# Altium schematic sheet Bop_it.SchDoc
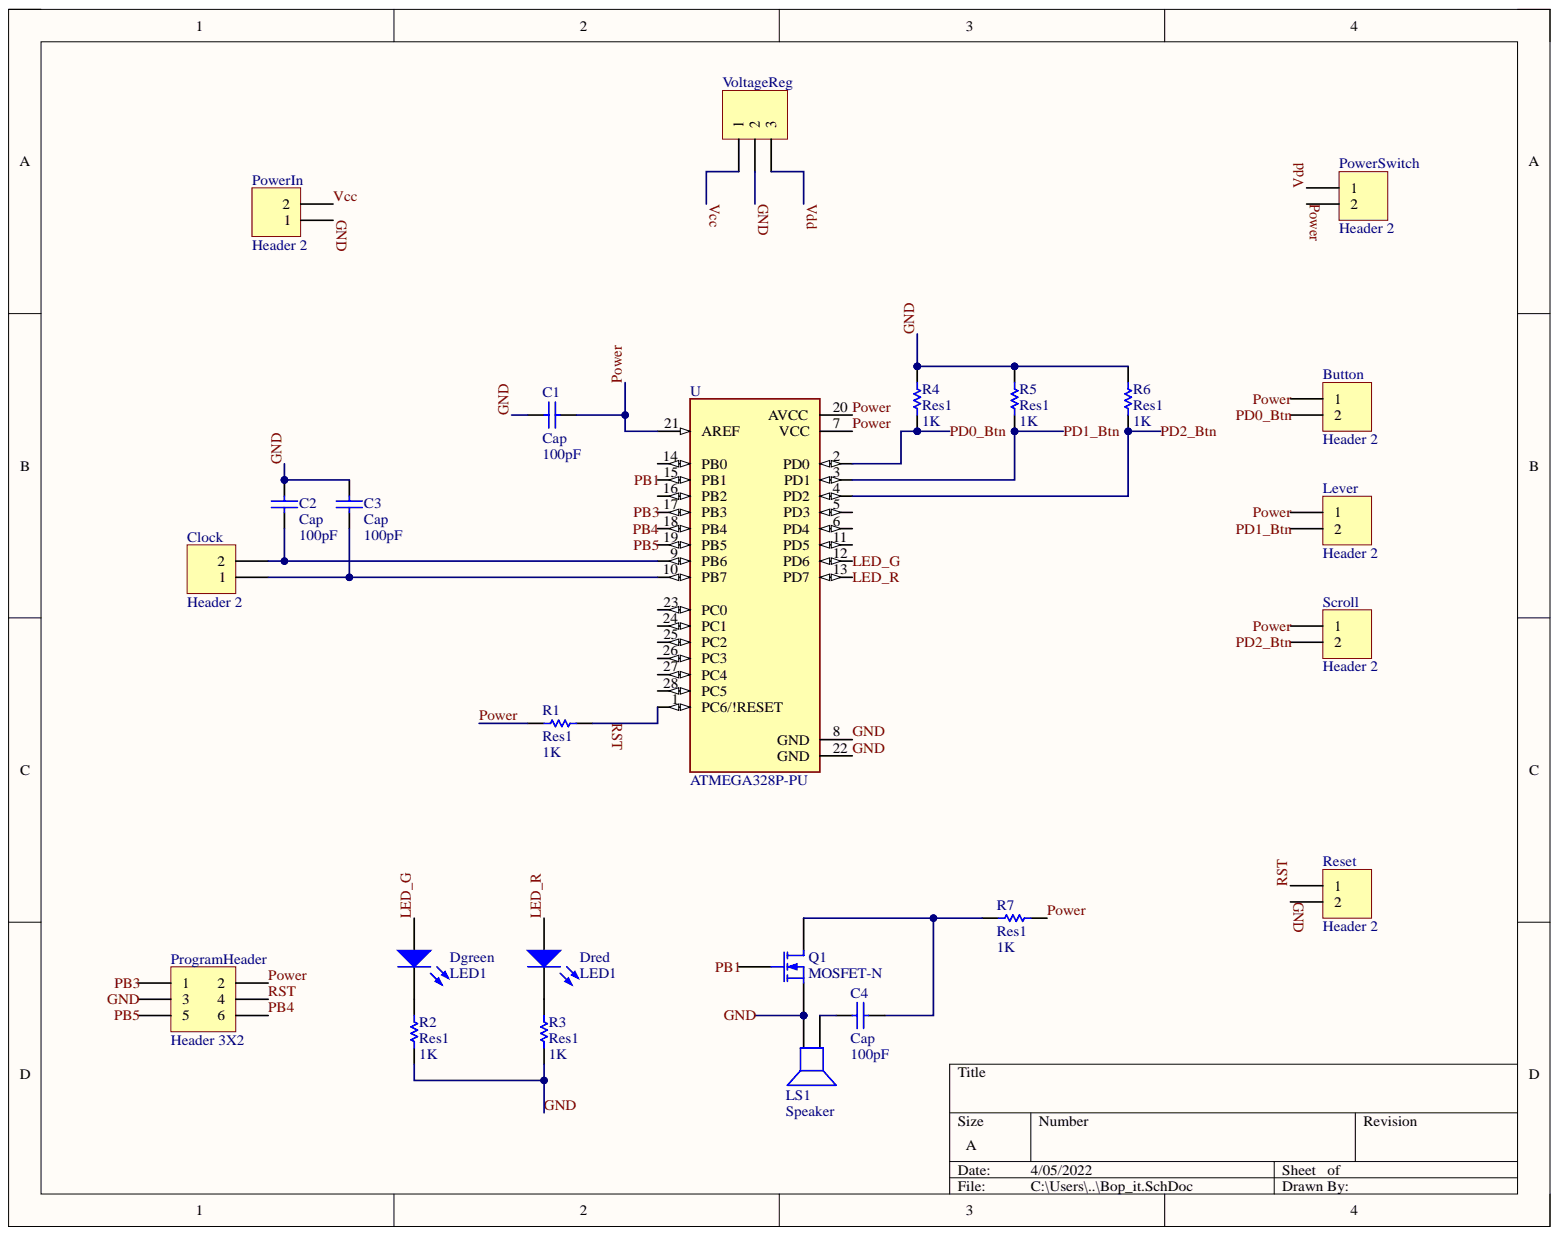
[diagram p0: Bop_it.SchDoc]

## Parts
Button  Header 2  [HDR1X2]
C1  Cap  value 100pF  [RAD-0.3]
C2  Cap  value 100pF  [RAD-0.3]
C3  Cap  value 100pF  [RAD-0.3]
C4  Cap  value 100pF  [RAD-0.3]
Clock  Header 2  [HDR1X2]
Dgreen  LED1  [LED-1]
Dred  LED1  [LED-1]
Lever  Header 2  [HDR1X2]
LS1  Speaker  [PIN2]
PowerIn  Header 2  [HDR1X2]
PowerSwitch  Header 2  [HDR1X2]
ProgramHeader  Header 3X2  [HDR2X3]
Q1  MOSFET-N  [E3]
R1  Res1  value 1K  [AXIAL-0.3]
R2  Res1  value 1K  [AXIAL-0.3]
R3  Res1  value 1K  [AXIAL-0.3]
R4  Res1  value 1K  [AXIAL-0.3]
R5  Res1  value 1K  [AXIAL-0.3]
R6  Res1  value 1K  [AXIAL-0.3]
R7  Res1  value 1K  [AXIAL-0.3]
Reset  Header 2  [HDR1X2]
Scroll  Header 2  [HDR1X2]
U  ATMEGA328P-PU  [DIP794W46P254L2967H457Q28B]
VoltageReg  (no value)  [HDR1X3]

## Nets
GND: C1.1 C2.2 C3.1 LS1.2 PowerIn.1 ProgramHeader.3 Q1.3 R2.2 R3.2 R4.1 R5.1 R6.1 Reset.2 U.8(GND) U.22(GND) VoltageReg.2
LED_G: Dgreen.1 U.12(PD6)
LED_R: Dred.1 U.13(PD7)
PB1: Q1.2 U.15(PB1)
PB3: ProgramHeader.1 U.17(PB3)
PB4: ProgramHeader.6 U.18(PB4)
PB5: ProgramHeader.5 U.19(PB5)
PD0_Btn: Button.2 R4.2 U.2(PD0)
PD1_Btn: Lever.2 R5.2 U.3(PD1)
PD2_Btn: R6.2 Scroll.2 U.4(PD2)
Power: Button.1 C1.2 Lever.1 PowerSwitch.2 ProgramHeader.2 R1.1 R7.1 Scroll.1 U.7(VCC) U.20(AVCC) U.21(AREF)
RST: ProgramHeader.4 R1.2 Reset.1 U.1(PC6/!RESET)
Vcc: PowerIn.2 VoltageReg.1
Vdd: PowerSwitch.1 VoltageReg.3
NetC2_1: C2.1 Clock.2 U.9(PB6)
NetC3_2: C3.2 Clock.1 U.10(PB7)
NetC4_1: C4.1 LS1.1
NetC4_2: C4.2 Q1.1 R7.2
NetDgreen_2: Dgreen.2 R2.1
NetDred_2: Dred.2 R3.1

Not connected: U 5(PD3) 6(PD4) 11(PD5) 14(PB0) 16(PB2) 23(PC0) 24(PC1) 25(PC2) 26(PC3) 27(PC4) 28(PC5)

## Library data of the parts
Button Clock Lever PowerIn PowerSwitch Reset Scroll = Header 2: Header, 2-Pin; Component Kind: Standard; LatestRevisionDate: 17-Jul-2002; LatestRevisionNote: Re-released for DXP Platform.; Library Name: Miscellaneous Connectors.IntLib; Signal Integrity: Connector; Publisher: Altium Limited
C1-C3 = Cap: Capacitor; Component Kind: Standard; LatestRevisionDate: 17-Jul-2002; LatestRevisionNote: Re-released for DXP Platform.; Library Name: Miscellaneous Devices.IntLib; PackageDescription: Radial Cap, Thru-Hole; 2 Leads; 0.3 in Pin Spacing; PackageReference: RAD-0.3; Published: 8-Jun-2000; Signal Integrity: Cap; Simulation: CAP; Publisher: Altium Limited
C4 = Cap: Capacitor; Value: 100pF; Component Kind: Standard; LatestRevisionDate: 17-Jul-2002; LatestRevisionNote: Re-released for DXP Platform.; Library Name: Miscellaneous Devices.IntLib; PackageDescription: Radial Cap, Thru-Hole; 2 Leads; 0.3 in Pin Spacing; PackageReference: RAD-0.3; Published: 8-Jun-2000; Signal Integrity: Cap; Simulation: CAP; Publisher: Altium Limited
Dgreen Dred = LED1: Typical RED GaAs LED; Component Kind: Standard; LatestRevisionDate: 08-Jul-2005; LatestRevisionNote: Mech Layer 1 removed.; Library Name: Miscellaneous Devices.IntLib; PackageDescription: LED; 2 Leads; PackageReference: LED-1; Published: 8-Jun-2000; Simulation: LED1; Publisher: Altium Limited
LS1 = Speaker: Loudspeaker; Component Kind: Standard; LatestRevisionDate: 17-Jul-2002; LatestRevisionNote: Re-released for DXP Platform.; Library Name: Miscellaneous Devices.IntLib; PackageReference: PIN2; Published: 24-Mar-1999; Publisher: Altium Limited
ProgramHeader = Header 3X2: Header, 3-Pin, Dual row; Component Kind: Standard; LatestRevisionDate: 17-Jul-2002; LatestRevisionNote: Re-released for DXP Platform.; Library Name: Miscellaneous Connectors.IntLib; Signal Integrity: Connector; Publisher: Altium Limited
Q1 = MOSFET-N: N-Channel MOSFET; Component Kind: Standard; LatestRevisionDate: 17-Jul-2002; LatestRevisionNote: Re-released for DXP Platform.; Library Name: Miscellaneous Devices.IntLib; PackageDescription: TO, Flat Index; 3 In-Line, Axial Leads; Body Dia. 4.6mm; Lead Dia. 0.5 mm (max); PackageReference: E3; PackageVersion: 1995/1996; Published: 8-Jun-2000; Simulation: NMOS; Publisher: Altium Limited
R1-R7 = Res1: Resistor; Component Kind: Standard; LatestRevisionDate: 17-Jul-2002; LatestRevisionNote: Re-released for DXP Platform.; Library Name: Miscellaneous Devices.IntLib; PackageDescription: Axial Device, Thru-Hole; 2 Leads; 0.3 in Pin Spacing; PackageReference: AXIAL-0.3; Published: 8-Jun-2000; Signal Integrity: Res; Simulation: RESISTOR; Publisher: Altium Limited
U = ATMEGA328P-PU: AVR AVRÂ® ATmega Microcontroller IC 8-Bit 20MHz 32KB _16K x 16_ FLASH 28-PDIP; MF: Microchip; Availability: Unavailable; Component Kind: Standard; Library Name: ATMEGA328P-PU.IntLib; MP: ATMEGA328P-PU; Package: PDIP-28 Atmel; Price: None; SnapEDA_Link: https://www.snapeda.com/parts/ATMEGA328P-PU/Microchip/view-part/44282/?ref=snap
VoltageReg = Header 3: Header, 3-Pin; Component Kind: Standard; LatestRevisionDate: 17-Jul-2002; LatestRevisionNote: Re-released for DXP Platform.; Library Name: Miscellaneous Connectors.IntLib; Signal Integrity: Connector; Publisher: Altium Limited
